# Altium schematic sheet ESP32-SCREEN.SchDoc(ESP32-SCREEN)
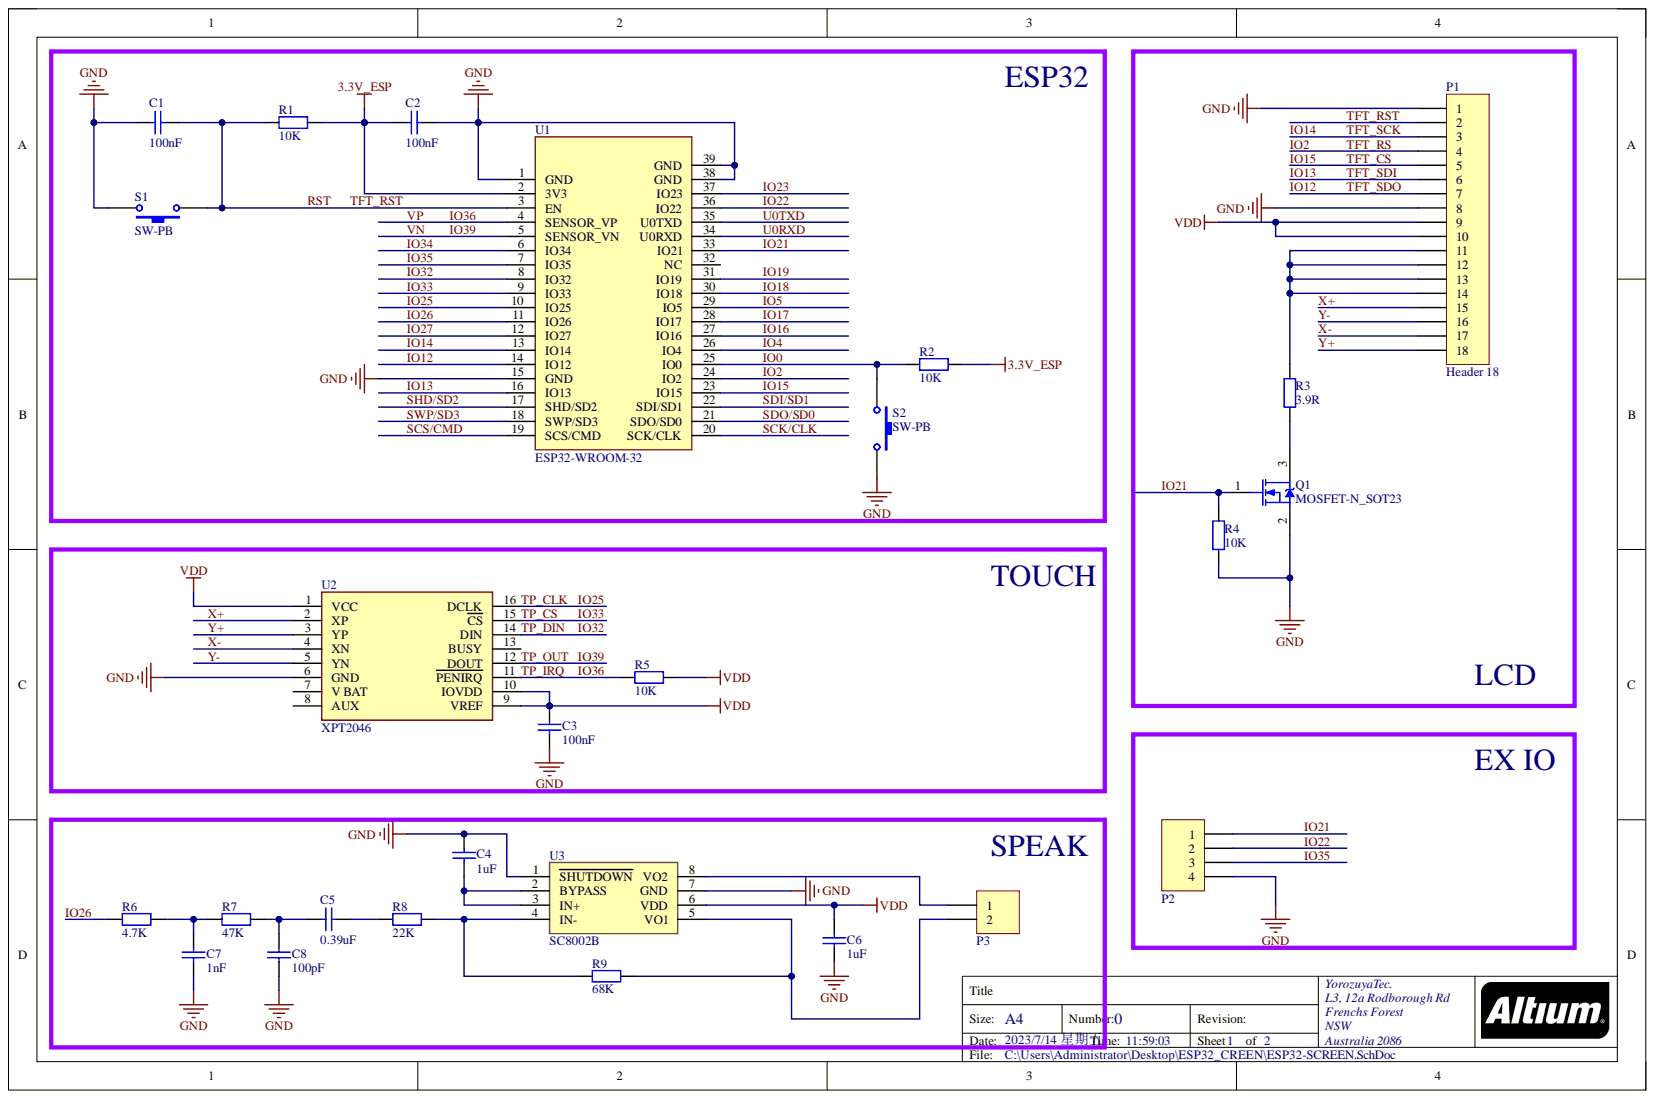
[diagram p0: ESP32-SCREEN.SchDoc]

## Parts
C1  value 100nF  [C0603]  (hidden comment: Cap)
C2  value 100nF  [C0603]  (hidden comment: Cap)
C3  value 100nF  [C0603]  (hidden comment: Cap)
C4  value 1uF  [C0603]  (hidden comment: Cap)
C5  value 0.39uF  [C0603]  (hidden comment: Cap)
C6  value 1uF  [C0603]  (hidden comment: Cap)
C7  value 1nF  [C0603]  (hidden comment: Cap)
C8  value 100pF  [C0603]  (hidden comment: Cap)
P1  Header 18  [2.8inch LCD]
P2  Header 4  [MX1.25S-4P]  (value not drawn)
P3  Header 2  [MX1.25S-2P]  (value not drawn)
Q1  MOSFET-N_SOT23  [SOT23]
R1  value 10K  [R0603]  (hidden comment: Res1)
R2  value 10K  [R0603]  (hidden comment: Res1)
R3  value 3.9R  [R0805]  (hidden comment: Res1)
R4  value 10K  [R0603]  (hidden comment: Res1)
R5  value 10K  [R0603]  (hidden comment: Res1)
R6  value 4.7K  [R0603]  (hidden comment: Res1)
R7  value 47K  [R0603]  (hidden comment: Res1)
R8  value 22K  [R0603]  (hidden comment: Res1)
R9  value 68K  [R0603]  (hidden comment: Res1)
S1  SW-PB  [SW_PB2_A1]
S2  SW-PB  [SW_PB2_A1]
U1  ESP32-WROOM-32  [ESP32-WROOM32]
U2  XPT2046  [TSSOP16]
U3  SC8002B  [SOP8]

## Nets
3.3V_ESP: C2.1 R1.2 R2.2 U1.2(3V3)
GND: C1.1 C2.2 C3.1 C4.1 C6.2 C7.1 C8.1 P1.1 P1.8 P2.4 Q1.2 R4.1 S1.2 S2.2 U1.1(GND) U1.15(GND) U1.38(GND) U1.39(GND) U2.6(GND) U3.1(SHUTDOWN) U3.7(GND)
VDD: C3.2 C6.1 P1.9 P1.10 R5.2 U2.1(VCC) U2.9(VREF) U2.10(IOVDD) U3.6(VDD)
IO0: R2.1 S2.1 U1.25(IO0)
IO2: P1.4 U1.24(IO2)
IO4: U1.26(IO4)
IO5: U1.29(IO5)
IO12: P1.7 U1.14(IO12)
IO13: P1.6 U1.16(IO13)
IO14: P1.3 U1.13(IO14)
IO15: P1.5 U1.23(IO15)
IO16: U1.27(IO16)
IO17: U1.28(IO17)
IO18: U1.30(IO18)
IO19: U1.31(IO19)
IO21: P2.1 Q1.1 R4.2 U1.33(IO21)
IO22: P2.2 U1.36(IO22)
IO23: U1.37(IO23)
IO25: U1.10(IO25) U2.16(DCLK)
IO26: R6.1 U1.11(IO26)
IO27: U1.12(IO27)
IO32: U1.8(IO32) U2.14(DIN)
IO33: U1.9(IO33) U2.15(CS)
IO34: U1.6(IO34)
IO35: P2.3 U1.7(IO35)
IO36: R5.1 U1.4(SENSOR_VP) U2.11(PENIRQ)
IO39: U1.5(SENSOR_VN) U2.12(DOUT)
RST: C1.2 P1.2 R1.1 S1.1 U1.3(EN)
SCK/CLK: U1.20(SCK/CLK)
SCS/CMD: U1.19(SCS/CMD)
SDI/SD1: U1.22(SDI/SD1)
SDO/SD0: U1.21(SDO/SD0)
SHD/SD2: U1.17(SHD/SD2)
SWP/SD3: U1.18(SWP/SD3)
U0RXD: U1.34(U0RXD)
U0TXD: U1.35(U0TXD)
X+: P1.15 U2.2(XP)
X-: P1.17 U2.4(XN)
Y+: P1.18 U2.3(YP)
Y-: P1.16 U2.5(YN)
NetC4_2: C4.2 U3.2(BYPASS) U3.3(IN+)
NetC5_1: C5.1 R8.1
NetC5_2: C5.2 C8.2 R7.2
NetC7_2: C7.2 R6.2 R7.1
NetP1_11: P1.11 P1.12 P1.13 P1.14 R3.2
NetP3_1: P3.1 U3.8(VO2)
NetP3_2: P3.2 R9.2 U3.5(VO1)
NetQ1_3: Q1.3 R3.1
NetR8_2: R8.2 R9.1 U3.4(IN-)

Not connected: U1 32(NC); U2 7(V_BAT) 8(AUX) 13(BUSY)

## Library data of the parts
C1-C8 = Cap: 贴片电容; Component Kind: Standard; LatestRevisionDate: 17-Jul-2002; LatestRevisionNote: Re-released for DXP Platform.; PackageReference: RAD-0.3; Published: 8-Jun-2000; Library Name: Sunny20181114.SchLib; Publisher: Altium Limited
P1 = Header 18: Header18; Component Kind: Standard; LatestRevisionDate: 17-Jul-2002; LatestRevisionNote: Re-released for DXP Platform.; Library Name: Sunny20181114.SchLib; Publisher: Altium Limited
P2 = Header 4: 接插件; Component Kind: Standard; LatestRevisionDate: 17-Jul-2002; LatestRevisionNote: Re-released for DXP Platform.; Library Name: Sunny20181114.SchLib; Publisher: Altium Limited
P3 = Header 2: 接插件; Component Kind: Standard; LatestRevisionDate: 17-Jul-2002; LatestRevisionNote: Re-released for DXP Platform.; Library Name: Sunny20181114.SchLib; Publisher: Altium Limited
Q1 = MOSFET-N_SOT23: PackageDocument: 1995/1996; Component Kind: Standard; Description: MOSFET-N_SOT23; LatestRevisionDate: 17-Jul-2002; LatestRevisionNote: Re-released for DXP Platform.; PackageReference: E3; Published: 8-Jun-2000; Library Name: Sunny20181114.SchLib; Publisher: Altium Limited
R1-R9 = Res1: 贴片电阻; Component Kind: Standard; LatestRevisionDate: 17-Jul-2002; LatestRevisionNote: Re-released for DXP Platform.; PackageReference: AXIAL-0.4; Published: 8-Jun-2000; Library Name: Sunny20181114.SchLib; Publisher: Altium Limited
S1 S2 = SW-PB: 微动开关; Component Kind: Standard; LatestRevisionDate: 17-Jul-2002; LatestRevisionNote: Re-released for DXP Platform.; PackageReference: SPST-2; Published: 24-Mar-1999; Library Name: Sunny20181114.SchLib; Publisher: Altium Limited
U1 = ESP32-WROOM-32: ESP32-WROOM-32模组; Component Kind: Standard; Library Name: Sunny20181114.SchLib
U2 = XPT2046: LCD电阻屏触摸芯片; Component Kind: Standard; Library Name: Sunny20181114.SchLib
U3 = SC8002B: Component Kind: Standard; Library Name: Sunny20181114.SchLib
